# Altium schematic sheet BuckBoost + CLF.SchDoc
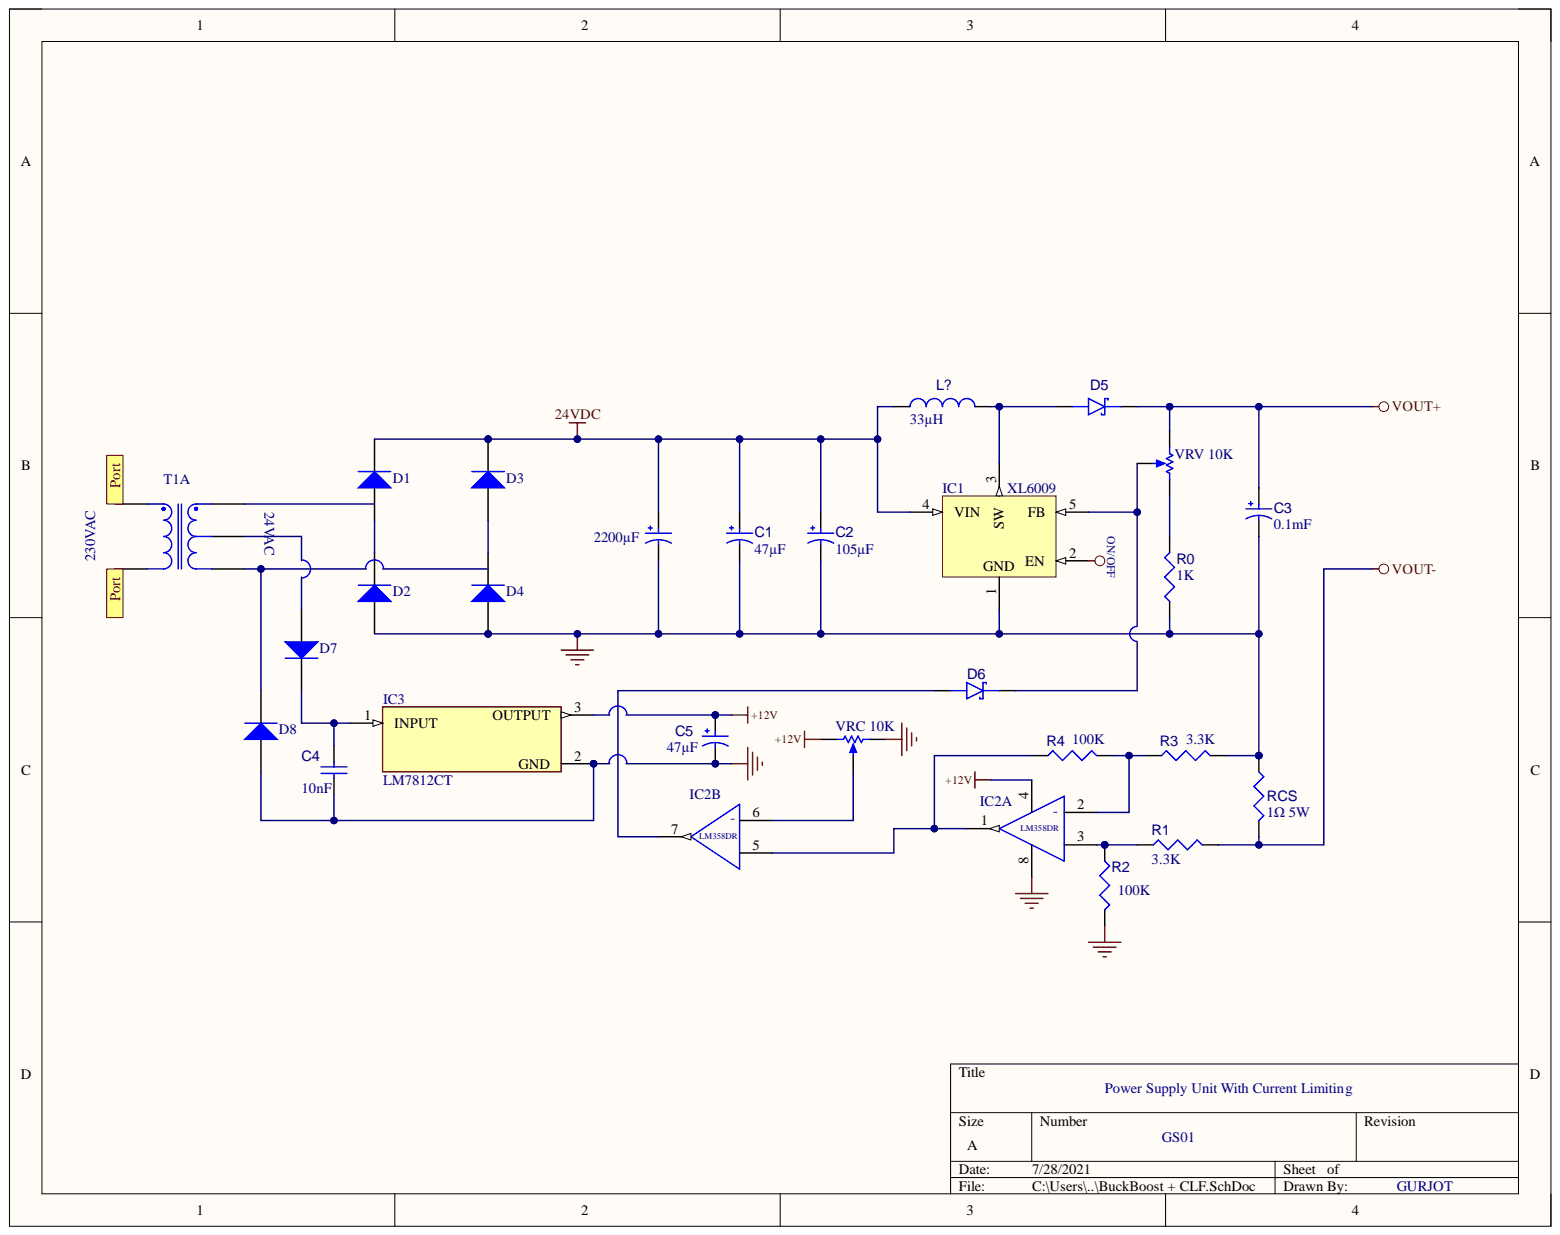
[diagram p0: BuckBoost + CLF.SchDoc]

## Parts
C1  value 47µF  [CAPPR65-350-1150X800X1250]  (hidden comment: ECA-2AM470)
C2  value 105µF  [CAPPR65-350-1150X800X1250]  (hidden comment: ECA-2AM470)
C3  value 0.1mF  [CAPPR65-500-2000X1000X2200]  (hidden comment: ECA1EM102)
C4  value 10nF  [CAPC0402(1005)60_L]  (hidden comment: Capacitor 10nF +/-20% 50V 0402)
C5  value 47µF  [CAPPR65-350-1150X800X1250]  (hidden comment: ECA-2AM470)
C?  value 2200µF  [CAPPR55-200-1100X500X1200]  (hidden comment: ECA1HM4R7)
D1  (no value)  [FAIR-DO-41-2]
D2  (no value)  [FAIR-DO-41-2]
D3  (no value)  [FAIR-DO-41-2]
D4  (no value)  [FAIR-DO-41-2]
D5  1N5825  [MicroSMP (DO-219AD)]  (value not drawn)
D6  1N5825  [MicroSMP (DO-219AD)]  (value not drawn)
D7  (no value)  [FAIR-DO-41-2]
D8  (no value)  [FAIR-DO-41-2]
IC1  XL6009  [KTT0005B_L]
IC2  LM358DR  [FP-D0008A-MFG]  (parts A,B)
IC3  LM7812CT  [FP-TO220B03-MFG]
L?  value 33µH  [TDK-VLCF5028-2_V]  (hidden comment: VLCF5028T-2R7N1R8-2)
R0  value 1K  [RESC0805(2012)_L]  (hidden comment: Resistor 1K +/-5% 0805 125 mW)
R1  value 3.3K  [RESC0805(2012)_L]  (hidden comment: Resistor 1K +/-5% 0805 125 mW)
R2  value 100K  [RESC0805(2012)_L]  (hidden comment: Resistor 1K +/-5% 0805 125 mW)
R3  value 3.3K  [RESC0805(2012)_L]  (hidden comment: Resistor 1K +/-5% 0805 125 mW)
R4  value 100K  [RESC0805(2012)_L]  (hidden comment: Resistor 1K +/-5% 0805 125 mW)
RCS  value 1Ω 5W  [RESC0805(2012)_L]  (hidden comment: Resistor 1K +/-5% 0805 125 mW)
T1  (no value)  [EATN-CTX02-14659_V]  (part A)
VRC_10K  3296W-1-103LF  [BOUR-3296W]  (value not drawn)
VRV_10K  3296W-1-103LF  [BOUR-3296W]  (value not drawn)

## Ports
Port

## Nets
24VDC: C1.1 C2.1 C?.1 D1.2 D3.2 IC1.4(VIN) L?.1
+12V: C5.1 IC2.4 VRC_10K.1
VOUT+: C3.1 D5.1 VRV_10K.1
VOUT-: R1.2 RCS.2
NetT1: T1. ; port Port
NetC1_2: C1.2 C2.2 C3.2 C5.2 C?.2 D2.1 D4.1 IC1.1 IC2.8 R0.1 R2.2 R3.2 RCS.1 VRC_10K.3
NetC4_1: C4.1 D8.1 IC3.2
NetC4_2: C4.2 D7.2 IC3.1
NetD1_1: D1.1 D2.2
NetD3_1: D3.1 D4.2
NetD5_2: D5.2 IC1.3(SW) L?.2
NetD6_2: D6.2 IC2.7
NetD8_2: D8.2 T1.
NetIC1_5: IC1.5(FB) VRV_10K.2
NetIC2_1: IC2.1 IC2.5 R4.1
NetIC2_2: IC2.2(-) R3.1 R4.2
NetIC2_3: IC2.3 R1.1 R2.1
NetIC2_6: IC2.6(-) VRC_10K.2
NetR0_2: R0.2 VRV_10K.3

Not connected: D6 1; D7 1; IC1 2(EN); IC3 3

## Library data of the parts
C1 C2 C5 = CMP-001-00036-7: Aluminum Electrolytic Capacitor, 47 uF, +/- 20%, 100 V, -40 to 85 degC, 2-Pin THD, RoHS, Bulk; Manufacturer: Panasonic; Ripple Current: 180mA; Voltage Rating: 100V; Capacitor Type: Electrolytic; Case/Package: Radial; Component Kind: Standard; Datasheet URL: http://industrial.panasonic.com/cdbs/www-data/pdf/RDF0000/ABA0000C1047.pdf; Datasheet Version: Mar-16; Diameter: 8mm; Lead Pitch: 3.5mm; Manufacturer URL: http://www.panasonic.com/; Max Operating Temperature: 85°C; Min Operating Temperature: -40°C; Mounting Technology: TH; Package Description: 2-Pin Radial Lead, Dia 8 mm, Height 12.5 mm; Package Quantity: 200; Package Reference: CAPPR65-350-1150X800X1250; Packaging: Bulk; Pins: 2; Ripple Current (AC): 180mA; RoHS Compliant: True; Tolerance: 20%; Library Name: Gurjot S
C3 = CMP-001-00023-8: Aluminum Electrolytic Capacitor, 1000 uF, +/- 20%, 25 V, -40 to 85 degC, 2-Pin THD, RoHS, Bulk; Manufacturer: Panasonic; Ripple Current: 850mA; Voltage Rating: 25V; Capacitor Type: Electrolytic; Case/Package: Radial; Component Kind: Standard; Datasheet URL: https://industrial.panasonic.com/cdbs/www-data/pdf/RDF0000/ABA0000C1218.pdf; Datasheet Version: Rev. 05, 10/2016; Diameter: 10mm; Lead Pitch: 5mm; Manufacturer URL: http://www.panasonic.com/; Max Operating Temperature: 85°C; Min Operating Temperature: -40°C; Mounting Technology: TH; Package Description: 2-Pin Radial Lead, Dia 10 mm, Height 22 mm; Package Quantity: 200; Package Reference: CAPPR65-500-2000X1000X2200; Packaging: Bulk; RoHS Compliant: True; Tolerance: 20%; Library Name: Gurjot S
C4 = CMP-001-00069-3: Chip Capacitor, 10nF +/-20%, 50V, 0402, Thickness 0.6 mm; Tolerance: 5%; Case/Package: 0402; Component Kind: Standard; Max Operating Temperature: 85°C; Min Operating Temperature: -55°C; Mounting Technology: SMT; Pins: 2; Voltage Rating: 50V; Library Name: Gurjot S
C? = CMP-001-00033-7: Aluminum Electrolytic Capacitor, 4.7 uF, +/- 20%, 50 V, -40 to 85 degC, 2-Pin THD, RoHS, Bulk; Manufacturer: Panasonic; Ripple Current: 45mA; Voltage Rating: 50V; Capacitor Type: Electrolytic; Case/Package: Radial; Component Kind: Standard; Datasheet URL: https://industrial.panasonic.com/cdbs/www-data/pdf/RDF0000/ABA0000C1218.pdf; Datasheet Version: Rev. 05, 10/2016; Diameter: 5mm; Lead Pitch: 2mm; Manufacturer URL: http://www.panasonic.com/; Max Operating Temperature: 85°C; Min Operating Temperature: -40°C; Mounting Technology: TH; Package Description: 2-Pin Radial Lead, Dia 5 mm, Height 12 mm; Package Quantity: 200; Package Reference: CAPPR55-200-1100X500X1200; Packaging: Bulk; Pins: 2; Ripple Current (AC): 45mA; RoHS Compliant: True; Tolerance: 20%; Library Name: Gurjot S
D1-D4 D7 D8 = CMP-004-00004-2: General Purpose Rectifier, 400 V, 1 A, -55 to 175 degC, 2-Pin DO-204AL (DO-41), RoHS, Tape and Reel; Manufacturer: Fairchild Semiconductor; Forward Current: 1A; Forward Voltage: 1.1V; Max Surge Current: 30A; Package Version: Rev. 2, 09/2008; Peak Reverse Current: 50A; Case/Package: DO-41-2; Component Kind: Standard; Datasheet URL: https://www.fairchildsemi.com/datasheets/1N/1N4004.pdf; Datasheet Version: Rev. 1.1.0, 11/2014; Diameter: 2.72mm; Manufacturer URL: https://www.fairchildsemi.com/; Max Operating Temperature: 175°C; Min Operating Temperature: -55°C; Mounting Technology: TH; Package Description: 2-Pin Axial Lead, Dia 2.375 mm, Body Length 4.63 mm; Package Quantity: 5000; Package Reference: DO-41-2; Package URL: http://www.fairchildsemi.com/dwg/DO/DO41A.pdf; Packaging: TapeandReel; Pins: 2; RoHS Compliant: True; Library Name: Gurjot S
D5 D6 = CMP-004-00024-3: Schottky Diodes & Rectifiers 1.0 Amp 40 Volt; Forward Voltage: 460mV; Max Surge Current: 25A; Component Kind: Standard; Max Operating Temperature: 150°C; Min Operating Temperature: -55°C; Mounting Technology: SMT; Pins: 2; Library Name: Gurjot S
IC1 = CMP-2000-06545-1: Simple Switcher(R) Power Converter 150 kHz Step-Down Voltage Regulator, 3 A, 4.5 to 40 V, -40 to 125 degC, 5-Pin TO-263 (KTT), Green (RoHS & no Sb/Br), Tape and Reel; Manufacturer: Texas Instruments; PartNumber: LM2596; No. of Outputs: 1; No. of Pins: 5; Output Current: 3A; Output Voltage: 37V; Package Version: 4200577-4/G, 01/2013; Primary Input Voltage: 40V; SVHC: NoSVHC(19-Dec-2012); Component Kind: Standard; Datasheet URL: http://www.ti.com/lit/ds/symlink/lm2596.pdf; Datasheet Version: Rev. C, 04/2013; Library Name: Altium Content Vault; Manufacturer URL: http://www.ti.com/; Mounting Technology: SurfaceMount; MSL: MSL3-168hours; Number of Outputs: 1; Operating Temperature Max: 125°C; Operating Temperature Min: -40°C; Operating Temperature Range: -40°Cto+125°C; Package Description: 5-Pin Plastic Flange Mount, Body 10.16 x 8.925 mm, Pitch 1.7 mm; Package Reference: KTT5; Package URL: http://www.ti.com/litv/pdf/mpsf007e; Voltage Regulator Case Style: TO-263
IC2 = CMP-1685-00009-2: IC OPAMP GP 2 CIRCUIT 8SOIC; Bandwidth: 700kHz; Common Mode Rejection Ratio: 65dB; Dual Supply Voltage: 9V; Input Bias Current: 250nA; Input Offset Voltage (Vos): 7mV; Max Dual Supply Voltage: 16V; Max Supply Voltage: 32V; Min Dual Supply Voltage: 1.5V; Min Supply Voltage: 3V; Nominal Supply Current: 1.2mA; Number of Amplifiers: 2; Operating Supply Current: 1mA; Output Current: 30mA; Slew Rate: 0.3V/µs; Voltage Gain: 100dB; Case/Package: SOIC; Component Kind: Standard; Contact Plating: Gold; Gain Bandwidth Product: 700kHz; Height: 1.58mm; Lead Free: Lead Free; Length: 4.9mm; Library Name: Altium Content Vault; Max Operating Temperature: 70°C; Min Operating Temperature: 0°C; Mount: Surface Mount; Number of Channels: 2; Number of Circuits: 2; Number of Elements: 2; Number of Pins: 8; Operating Supply Voltage: 16V; Output Current per Channel: 30mA; Package Quantity: 2500; Packaging: Tape and Reel; Power Supply Rejection Ratio (PSRR): 65dB; Radiation Hardening: No; REACH SVHC: No SVHC; Unity Gain Bandwidth Product: 700kHz; Width: 3.91mm
IC3 = CMP-07128-000015-1: IC REG LINEAR 12V 1A TO220-3; Manufacturer: ON Semiconductor; Obsolete Part: true; Accuracy Percentage: 4%; Dropout Voltage: 2V; Max Supply Voltage: 35V; Nominal Supply Current: 5.1mA; Number Of Outputs: 1; Output Type: Fixed; Standoff Height: 2.7mm; Automotive: No; Category: IC; Component Kind: Standard; ComponentLink2Description: Datasheet; ComponentLink2URL: https://www.mouser.in/datasheet/2/308/LM7812-1125088.pdf; Device Class L1: Integrated Circuits (ICs); Device Class L2: Power Management ICs; Device Class L3: Voltage Regulators - Linear; Digikey Description: IC REG LINEAR 12V 1A TO220-3; Height: 20.4mm; Ipc Land Pattern Name: TO-220; Lead Free: Yes; Library Name: Altium Content Vault; Manufacturer Part Number: LM7812CT; Octopart: https://octopart.com/lm7812ct-on+semiconductor-14383031; Output Current: 1A; Output Voltage: 12V; Package: TO220B03; RoHS: TRUE; Temperature: -40°C to +125°C; Temperature Range High: +125°C; Temperature Range Low: -40°C
L? = CMP-006-00017-6: Inductor for Power Circuits, 2.7 uH, +/- 30%,100 KHz, 1.82 A, -40 to 105 degC, 2-Pin SMD, RoHS, Reel; Manufacturer: TDK; Core Material: Ferrite; Current Rating: 1.82A; Resistance: 0.033Ohm; Shielding: Shielded; Case/Package: 5028; Component Kind: Standard; Datasheet URL: https://product.tdk.com/info/en/catalog/datasheets/inductor_commercial_power_vlcf5028-2_en.pdf; Datasheet Version: Apr-16; Inductance Tolerance: 30%; Max Operating Temperature: 105°C; Min Operating Temperature: -40°C; Mounting Technology: SM; Package Description: 2-Pin Surface Mount Device, Body 5.2 x 5.3 mm; Package Quantity: 500; Package Reference: VLCF5028-2; Packaging: TapeandReel; RoHS Compliant: True; Saturation Current: 1.82A; Tolerance: 30%; Library Name: Gurjot S
R0-R4 RCS = CMP-009-00118-3: Chip Resistor, 1 kOhms, +/-5%, 125 mW, -55 to 155 degC, 0805; Power: 125mW; Tolerance: 5%; Case/Package: 0805; Component Kind: Standard; Max Operating Temperature: 155°C; Min Operating Temperature: -55°C; Pins: 2; Library Name: Gurjot S
T1 = CMP-012-00009-3: Transformer Push-Pull, 547 uH, ER 9.5, 8-Pin SMT, RoHS, Tape and Reel; Manufacturer: EATON; Case/Package: SMD; Component Kind: Standard; Datasheet URL: https://datasheet.ciiva.com/36178/1771317-36178918.pdf; Datasheet Version: Rev. H, 09/2006; Mounting Technology: SurfaceMount; Package Description: 8-Pin Surface Mount Technology, Body 10 x 8.1 mm, Pitch 2 mm; Package Reference: CTX02-14659; Pins: 8; RoHS Compliant: True; Library Name: Gurjot S
VRC_10K VRV_10K = CMP-009-00038-2: Square Trimpot(R) Trimming Potentiometer, 10 KOhm, +/- 10%, 0.5 W, -55 to 125 degC, 3-Pin THD, RoHS, Tube; Manufacturer: Bourns; Power: 500mW; Resistance: 10k; Voltage Rating: 300V; Case/Package: 3296W; Component Kind: Standard; Datasheet URL: http://www.bourns.com/docs/Product-Datasheets/3296.pdf; Datasheet Version: May-16; Manufacturer URL: http://www.bourns.com/; Max Operating Temperature: 150°C; Min Operating Temperature: -55°C; Mounting Technology: TH; Package Description: 3-Pin Through Hole Device, Body 9.53 x 4.83 mm, Pitch 2.54 mm; Package Quantity: 50; Package Reference: 3296W; Packaging: Rail/Tube; RoHS Compliant: True; Tolerance: 10%; Library Name: Gurjot S
